# Altium schematic sheet POWER.SchDoc(POWER)
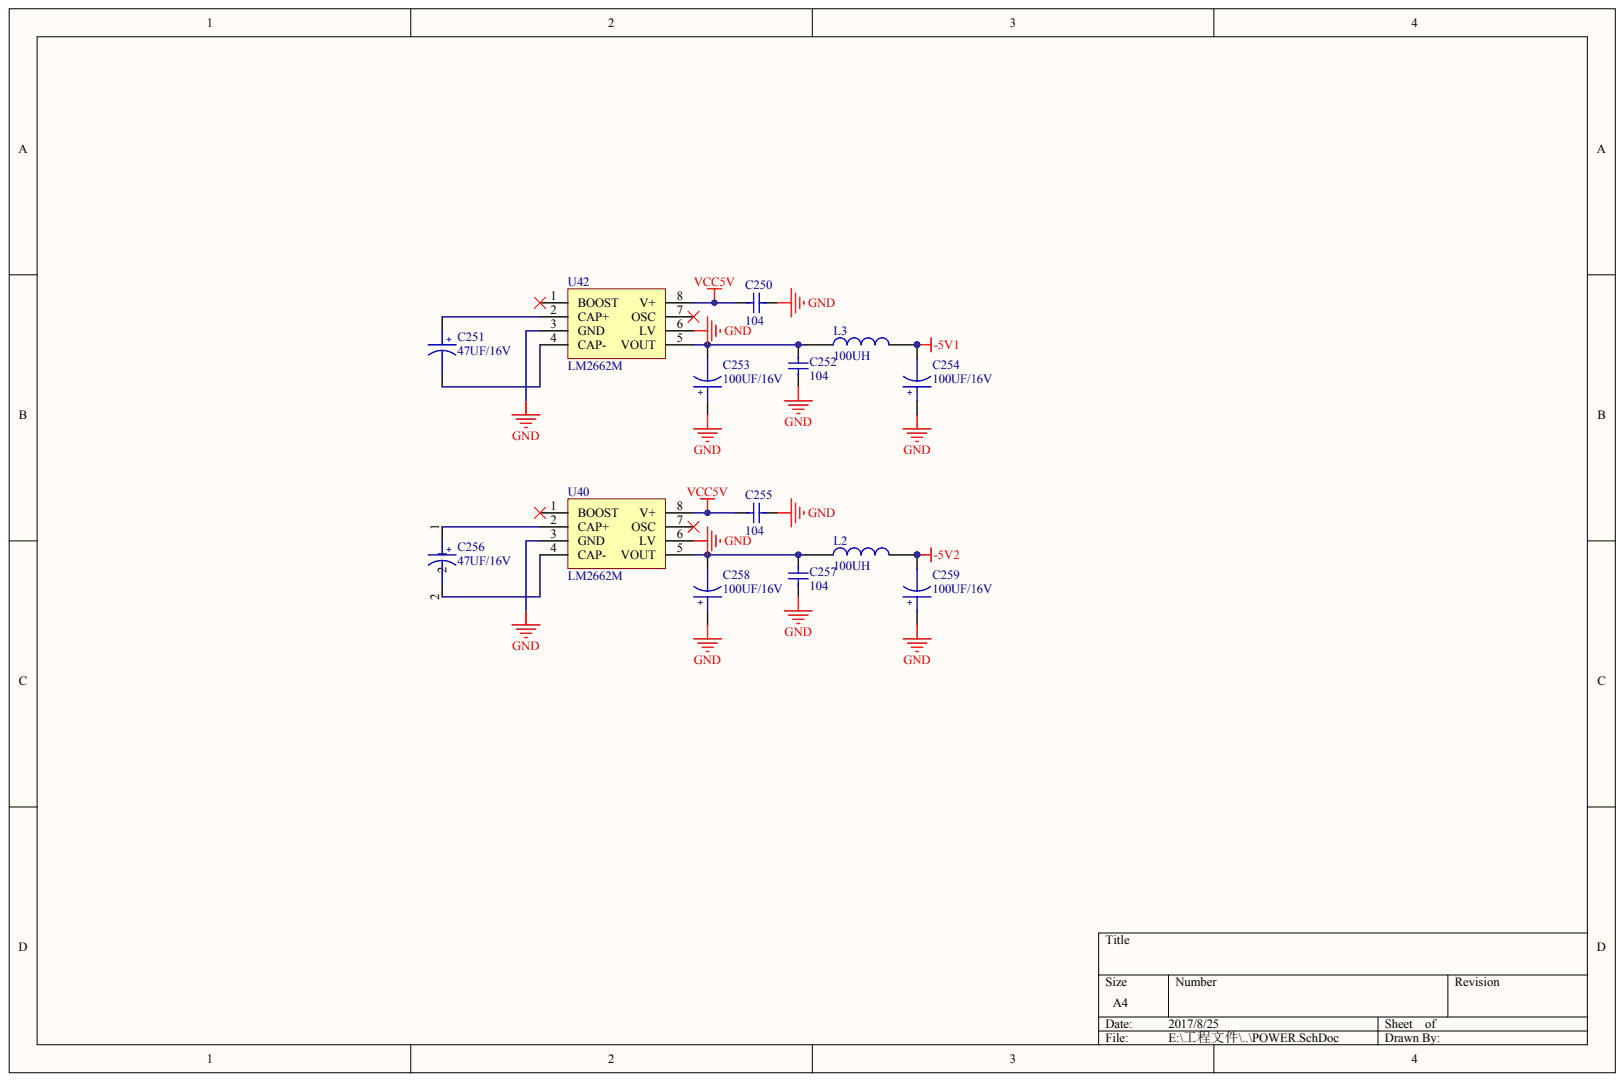
[diagram p0: POWER.SchDoc]

## Parts
C250  104
C251  47UF/16V
C252  104
C253  100UF/16V
C254  100UF/16V
C255  104
C256  47UF/16V
C257  104
C258  100UF/16V
C259  100UF/16V
L2  100UH
L3  100UH
U40  LM2662M
U42  LM2662M

## Nets
-5V1: C254.2 L3.2
-5V2: C259.2 L2.2
GND: C250.1 C252.1 C253.1 C254.1 C255.1 C257.1 C258.1 C259.1 U40.3(GND) U40.6(LV) U42.3(GND) U42.6(LV)
VCC5V: C250.2 C255.2 U40.8(V+) U42.8(V+)
NetC251_1: C251.1 U42.2(CAP+)
NetC251_2: C251.2 U42.4(CAP-)
NetC252_2: C252.2 C253.2 L3.1 U42.5(VOUT)
NetC256_1: C256.1 U40.2(CAP+)
NetC256_2: C256.2 U40.4(CAP-)
NetC257_2: C257.2 C258.2 L2.1 U40.5(VOUT)

Not connected: U40 1(BOOST) 7(OSC); U42 1(BOOST) 7(OSC)
